# Altium schematic sheet Power Board-PowerSource.SchDoc
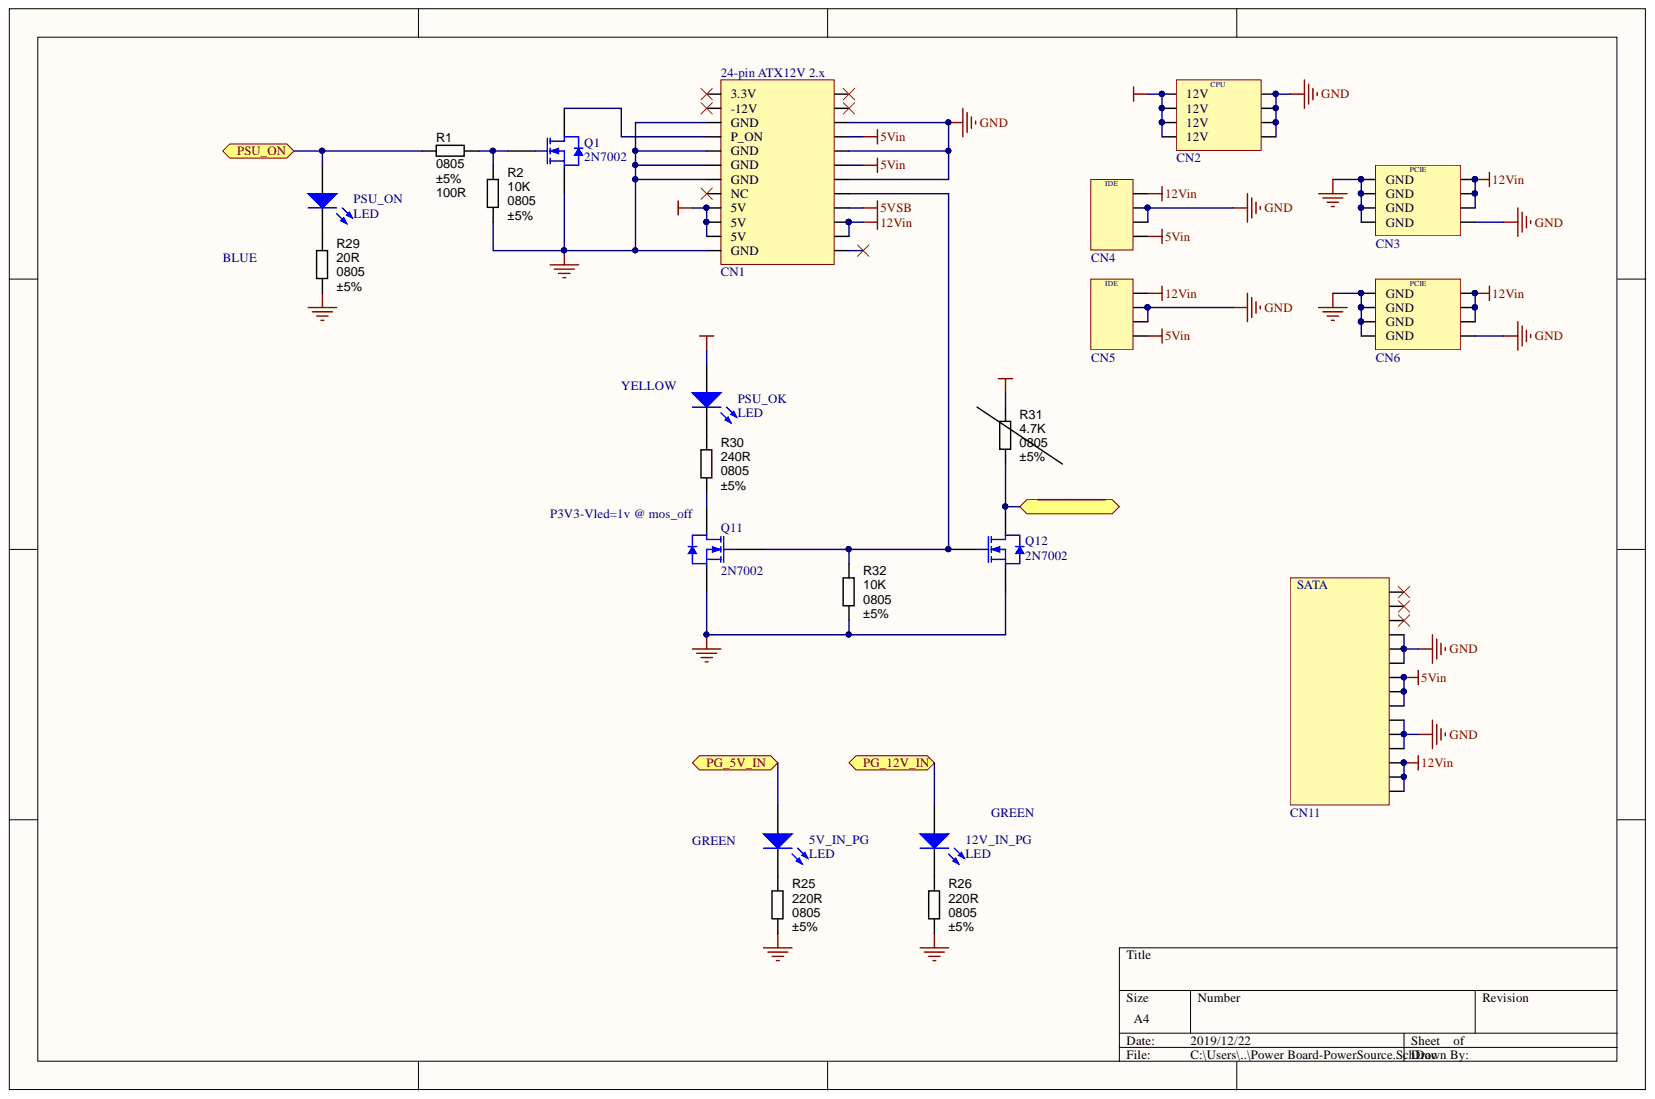
[diagram p0: Power Board-PowerSource.SchDoc]

## Parts
5V_IN_PG  LED  [LED-0805]
12V_IN_PG  LED  [LED-0805]
CN1  24-pin ATX12V 2.x  [5569 4.2mm Pitch 24.2 Right Angle]
CN2  CPU 8 PIN  [5569 4.2mm Pitch 08.2]  (value not drawn)
CN3  PCIE 8 PIN  [5569 4.2mm Pitch 08.2 PCIE]  (value not drawn)
CN4  IDE Power  [IDE 5.08mm 04.1]  (value not drawn)
CN5  IDE Power  [IDE 5.08mm 04.1]  (value not drawn)
CN6  PCIE 8 PIN  [5569 4.2mm Pitch 08.2 Right Angle PCIE]  (value not drawn)
CN11  SATA 15P  [SATA 15P Right Angle]  (value not drawn)
PSU_OK  LED  [LED-0805]
PSU_ON  LED  [LED-0805]
Q1  2N7002  [SOT23]
Q11  2N7002  [SOT23]
Q12  2N7002  [SOT23]
R1  value 100R  Package / Case: 0805  Tolerance: ±5%  [RCS0805-e3]  (hidden comment: Thick Film)
R2  value 10K  Tolerance: ±5%  [RCS0805-e3]  (hidden comment: RES_10K_0805_5%)
R25  value 220R  Package / Case: 0805  Tolerance: ±5%  [RCS0805-e3]  (hidden comment: RES_220R_0805_5%)
R26  value 220R  Package / Case: 0805  Tolerance: ±5%  [RCS0805-e3]  (hidden comment: RES_220R_0805_5%)
R29  value 20R  Package / Case: 0805  Tolerance: ±5%  [RCS0805-e3]  (hidden comment: RES_20R_0805_5%)
R30  value 240R  Package / Case: 0805  Tolerance: ±5%  [RCS0805-e3]  (hidden comment: RES_240R_0805_5%)
R31  value 4.7K  Package / Case: 0805  Tolerance: ±5%  [RCS0805-e3]  (hidden comment: RES_4.7K_0805_5%)  (no BOM)
R32  value 10K  Package / Case: 0805  Tolerance: ±5%  [RCS0805-e3]  (hidden comment: RES_10K_0805_5%)

## Ports
PG_5V_IN, PG_12V_IN, PSU_ON, ~{PSU_GOOD}

## Nets
5Vin: CN1.4 CN1.6 CN1.21(5V) CN1.22(5V) CN1.23(5V) CN4.4 CN5.4 CN11.7 CN11.8 CN11.9
5VSB: CN1.9
12Vin: CN1.10 CN1.11 CN2.5(12V) CN2.6(12V) CN2.7(12V) CN2.8(12V) CN3.1 CN3.2 CN3.3 CN4.1 CN5.1 CN6.1 CN6.2 CN6.3 CN11.13 CN11.14 CN11.15
GND: CN1.3 CN1.5 CN1.7 CN1.15(GND) CN1.17(GND) CN1.18(GND) CN1.19(GND) CN1.24(GND) CN2.1 CN2.2 CN2.3 CN2.4 CN3.4 CN3.5(GND) CN3.6(GND) CN3.7(GND) CN3.8(GND) CN4.2 CN4.3 CN5.2 CN5.3 CN6.4 CN6.5(GND) CN6.6(GND) CN6.7(GND) CN6.8(GND) CN11.4 CN11.5 CN11.6 CN11.10 CN11.11 CN11.12 Q1.2 Q11.2 Q12.2 R2.2 R25.2 R26.2 R29.2 R32.2
P3V3: PSU_OK.1 R31.1
~{PSU_GOOD}: Q12.3 R31.2 ; port ~{PSU_GOOD}
PG_5V_IN: 5V_IN_PG.1 ; port PG_5V_IN
PG_12V_IN: 12V_IN_PG.1 ; port PG_12V_IN
PSU_ON: PSU_ON.1 R1.1 ; port PSU_ON
Net5V_IN_PG_2: 5V_IN_PG.2 R25.1
Net12V_IN_PG_2: 12V_IN_PG.2 R26.1
NetCN1_8: CN1.8 Q11.1 Q12.1 R32.1
NetCN1_16: CN1.16(P_ON) Q1.3
NetPSU_OK_2: PSU_OK.2 R30.1
NetPSU_ON_2: PSU_ON.2 R29.1
NetQ1_1: Q1.1 R1.2 R2.1
NetQ11_3: Q11.3 R30.2

Not connected: CN1 1 2 12 13(3.3V) 14(-12V) 20(NC); CN11 1 2 3

## Library data of the parts
5V_IN_PG 12V_IN_PG PSU_OK PSU_ON = LED: Typical INFRARED GaAs LED; Component Kind: Standard; LatestRevisionDate: 08-Jul-2005; LatestRevisionNote: Mech Layer 1 removed.; PackageDescription: LED; 2 Leads; PackageReference: LED-0; Published: 8-Jun-2000; Simulation: LED0; Library Name: roger225_Altium_Library.IntLib; Publisher: Altium Limited
Q1 Q11 Q12 = 2N7002: N-channel Enhancement Mode Field-effect Transistor; Component Kind: Standard; ComponentLink1Description: Manufacturer Link; ComponentLink1URL: http://www.semiconductors.philips.com/; ComponentLink2Description: Datasheet; ComponentLink2URL: http://www.semiconductors.philips.com/acrobat/datasheets/2N7002_4.pdf; DatasheetVersion: 27-Jul-2000; PackageDescription: SOT-23; 3 Leads; Body 3.0 x 2.5 mm, inc. leads (LxW max); PackageReference: SOT23; PackageVersion: May-1999; Published: 17-Sep-2003; Simulation: 2N7002; Library Name: roger225_Altium_Library.IntLib; Publisher: Altium Limited
R1 = RES_100R_0805_5%: Resistor SMD chip 100 Ohm 0.125W 5% 0805; Ratings: 1/8W; SCDType: spec; Value: 100R; Component Kind: Standard; Supplier 1: Digi-Key; Supplier Part Number 1: 541-1.00KCCT-ND; Library Name: roger225_Altium_Library.IntLib
R2 R32 = RES_10K_0805_5%: Resistor SMD chip 10k Ohm 0.125W 5% 0805; Manufacturer 1: Vishay/Dale; Manufacturer Part Number 1: CRCW080510K0JNEA; Ratings: 1/8W; SCDType: spec; Component Kind: Standard; Library Name: roger225_Altium_Library.IntLib
  R2: Package / Case: 0805
R25 R26 = RES_220R_0805_5%: Resistor SMD chip 220 Ohm 0.125W 5% 0805; Ratings: 1/8W; SCDType: spec; Component Kind: Standard; Library Name: roger225_Altium_Library.IntLib
R29 = RES_20R_0805_5%: Resistor SMD chip 20 Ohm 0.125W 5% 0805; Ratings: 1/8W; SCDType: spec; Component Kind: Standard; Library Name: roger225_Altium_Library.IntLib
R30 = RES_240R_0805_5%: Resistor SMD chip 240 Ohm 0.125W 5% 0805; Ratings: 1/8W; SCDType: spec; Component Kind: Standard; Library Name: roger225_Altium_Library.IntLib
R31 = RES_4.7K_0805_5%: Resistor SMD chip 4.7k Ohm 0.125W 5% 0805; Manufacturer 1: Vishay/Dale; Manufacturer Part Number 1: CRCW08054K70JNEA; Ratings: 1/8W; SCDType: spec; Library Name: roger225_Altium_Library.IntLib
CN1 = 24-pin ATX12V 2.x: Component Kind: Standard; Description: 24-pin ATX12V 2.x; Library Name: ATX Connector.IntLib
CN2 = CPU 8 PIN: Component Kind: Standard; Description: CPU 8 PIN; Library Name: ATX Connector.IntLib
CN3 CN6 = PCIE 8 PIN: Component Kind: Standard; Description: PCIE 8 PIN; Library Name: ATX Connector.IntLib
CN4 CN5 = IDE Power: Component Kind: Standard; Description: IDE Power; Library Name: ATX Connector.IntLib
CN11 = SATA 15P: Component Kind: Standard; Description: SATA 15P; Library Name: ATX Connector.IntLib
